# Altium schematic sheet DC_DC_5V_2.SchDoc
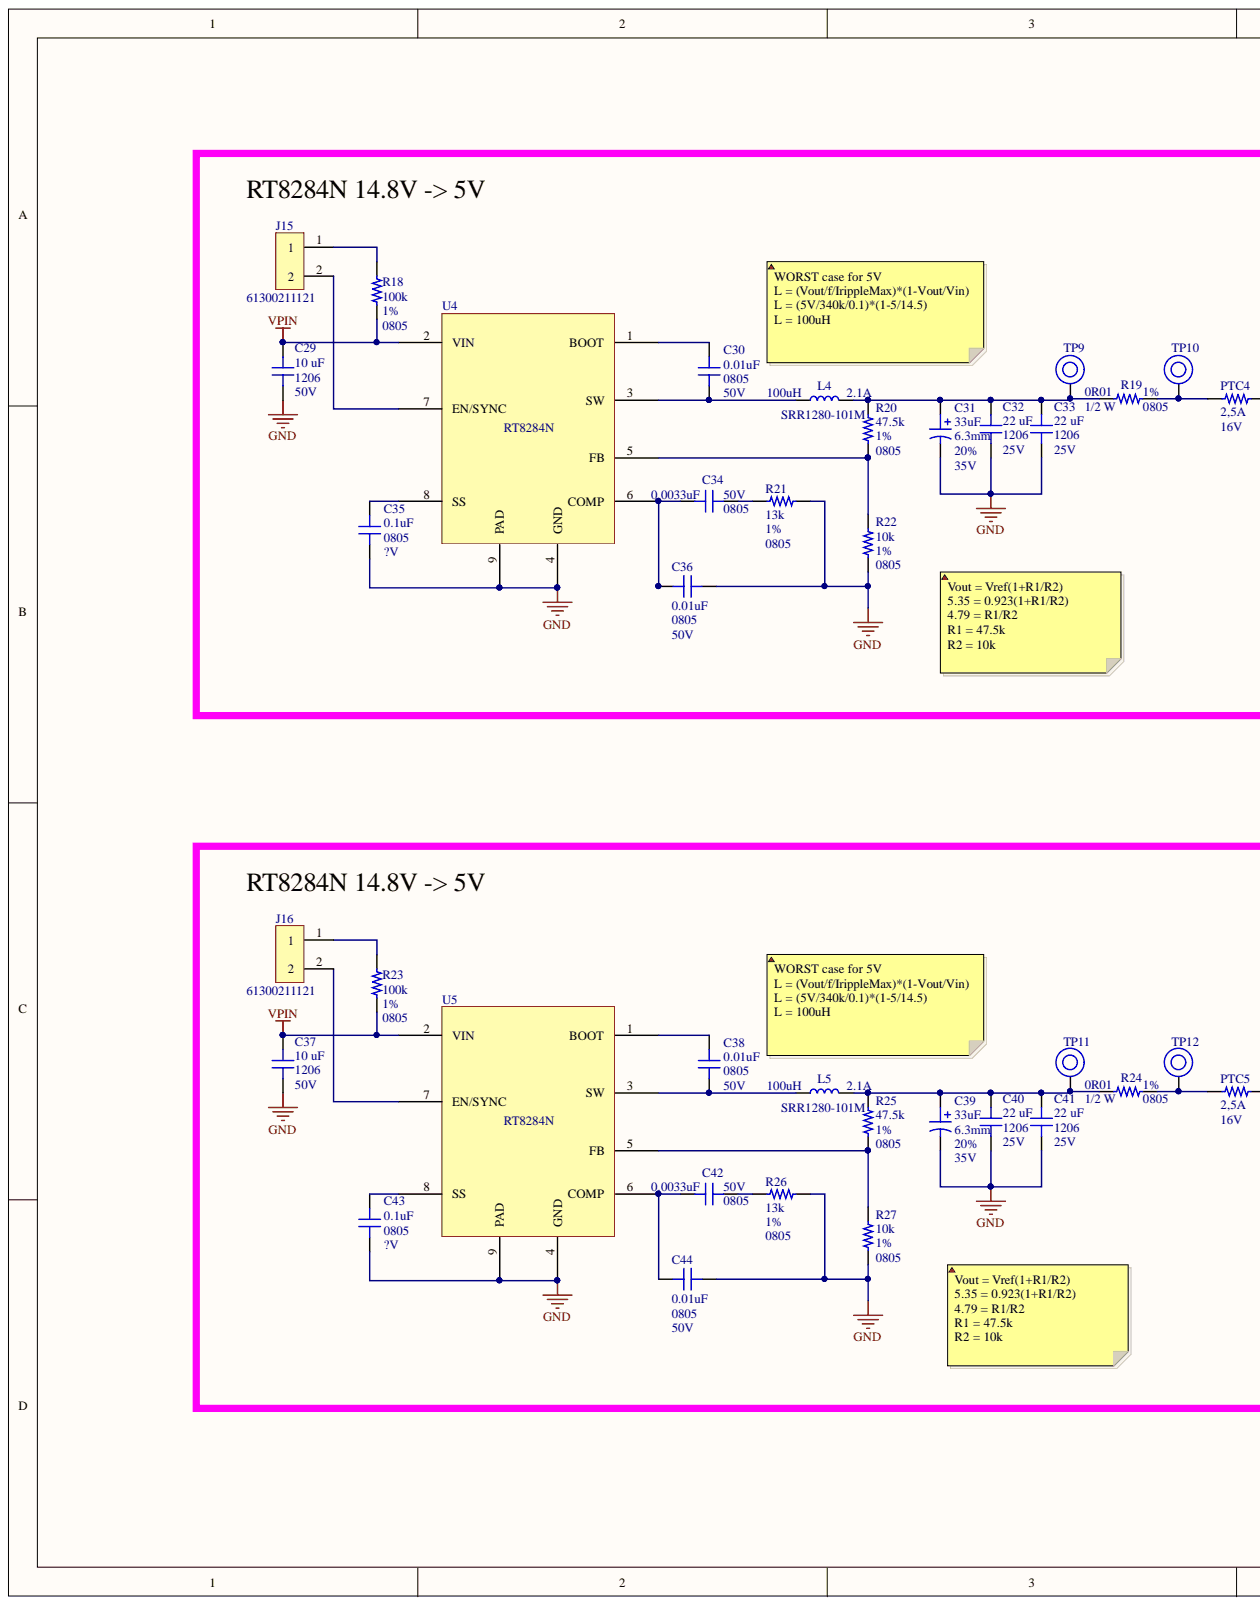
[diagram p0: DC_DC_5V_2.SchDoc, left]
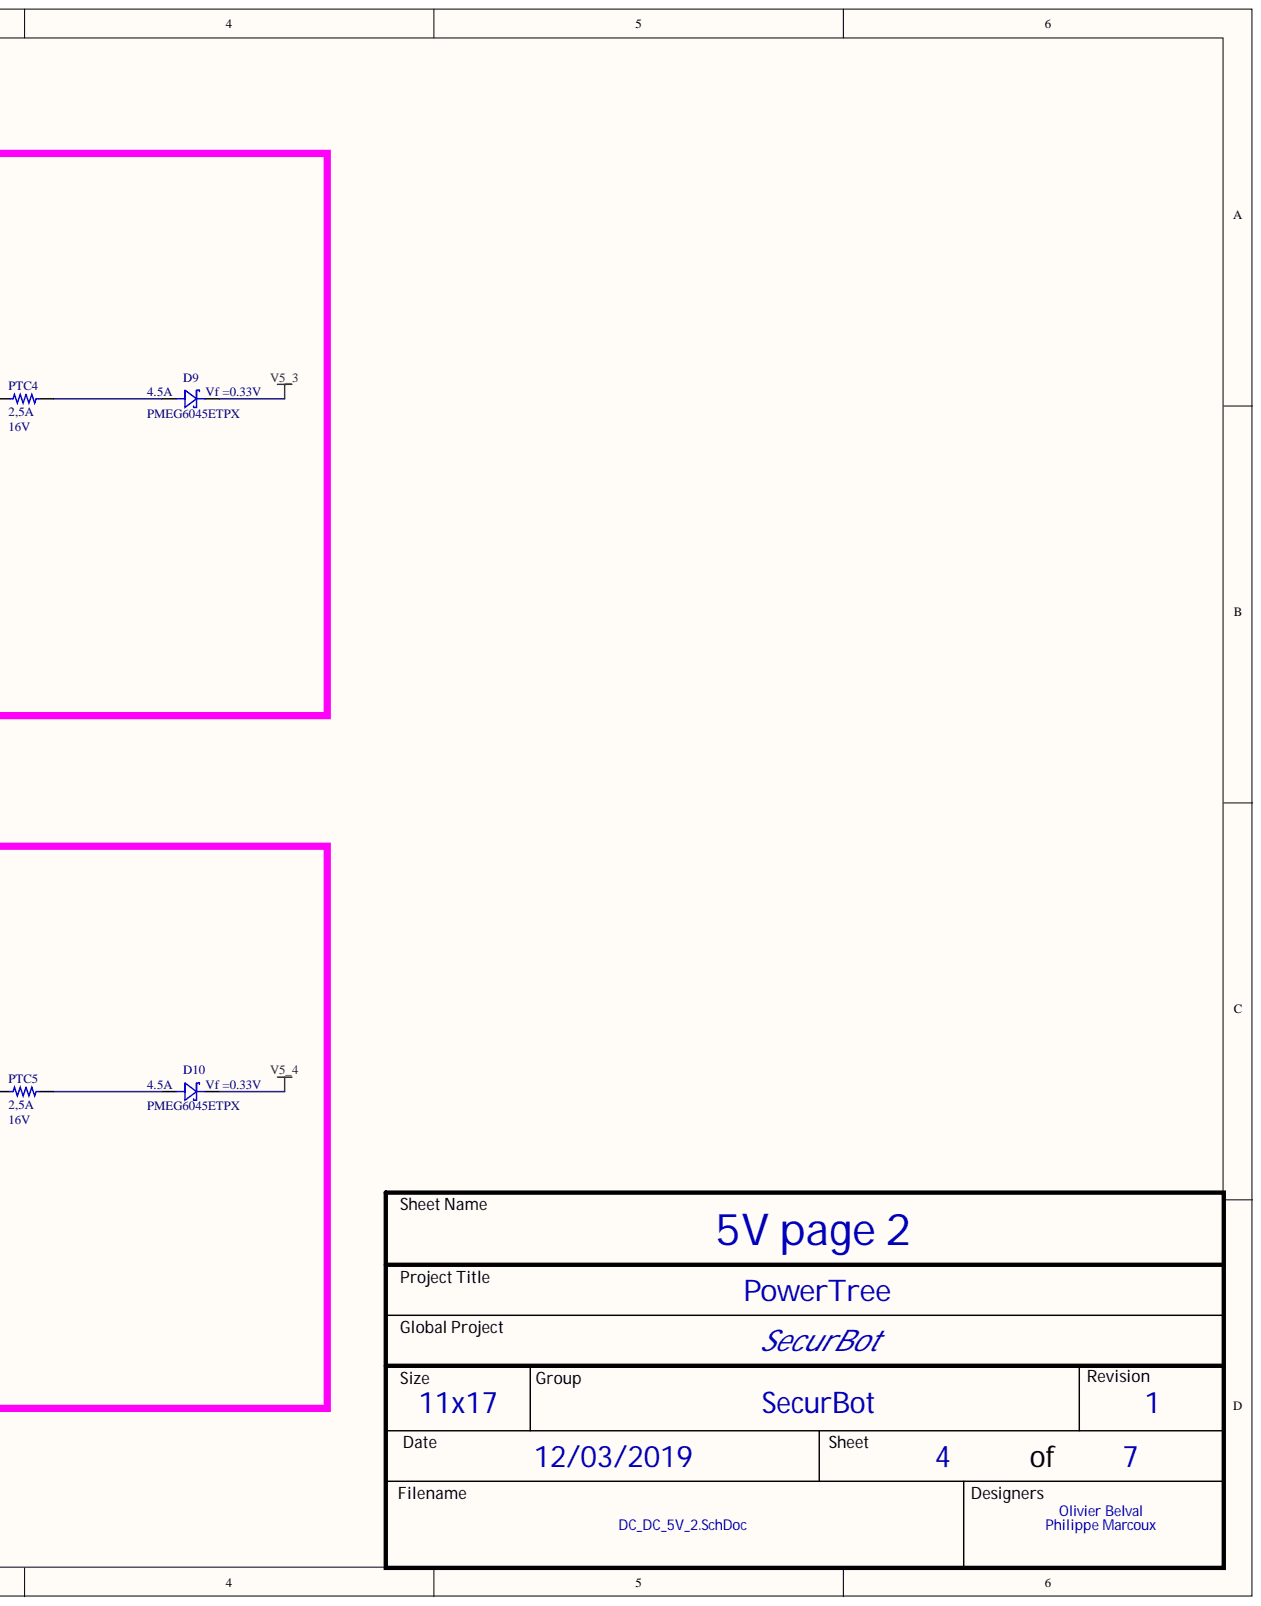
[diagram p1: DC_DC_5V_2.SchDoc, right]

## Parts
C29  value 10 uF  Package: 1206  Voltage: 50V  [CAP_1206]  (hidden comment: CAP - 1206)
C30  value 0.01uF  Package: 0805  Voltage: 50V  [CAP_0805]  (hidden comment: CAP - 0805)
C31  value 33uF  Package: 6.3mm  Tolerance: 20%  Voltage: 35V  [CAP ALU - 6.3mm DIA]  (hidden comment: CAP ALU - 6.3mm)
C32  value 22 uF  Package: 1206  Voltage: 25V  [CAP_1206]  (hidden comment: CAP - 1206)
C33  value 22 uF  Package: 1206  Voltage: 25V  [CAP_1206]  (hidden comment: CAP - 1206)
C34  value 0.0033uF  Package: 0805  Voltage: 50V  [CAP_0805]  (hidden comment: CAP - 0805)
C35  value 0.1uF  Package: 0805  Voltage: ?V  [CAP_0805]  (hidden comment: CAP - 0805)
C36  value 0.01uF  Package: 0805  Voltage: 50V  [CAP_0805]  (hidden comment: CAP - 0805)
C37  value 10 uF  Package: 1206  Voltage: 50V  [CAP_1206]  (hidden comment: CAP - 1206)
C38  value 0.01uF  Package: 0805  Voltage: 50V  [CAP_0805]  (hidden comment: CAP - 0805)
C39  value 33uF  Package: 6.3mm  Tolerance: 20%  Voltage: 35V  [CAP ALU - 6.3mm DIA]  (hidden comment: CAP ALU - 6.3mm)
C40  value 22 uF  Package: 1206  Voltage: 25V  [CAP_1206]  (hidden comment: CAP - 1206)
C41  value 22 uF  Package: 1206  Voltage: 25V  [CAP_1206]  (hidden comment: CAP - 1206)
C42  value 0.0033uF  Package: 0805  Voltage: 50V  [CAP_0805]  (hidden comment: CAP - 0805)
C43  value 0.1uF  Package: 0805  Voltage: ?V  [CAP_0805]  (hidden comment: CAP - 0805)
C44  value 0.01uF  Package: 0805  Voltage: 50V  [CAP_0805]  (hidden comment: CAP - 0805)
D9  Current: 4.5A  Part number: PMEG6045ETPX  Vf: Vf =0.33V  [DO-214AC_SOD128]  (hidden comment: SCHOTTKEY)
D10  Current: 4.5A  Part number: PMEG6045ETPX  Vf: Vf =0.33V  [DO-214AC_SOD128]  (hidden comment: SCHOTTKEY)
J15  Part number: 61300211121  [HDR1X2]  (hidden comment: Header 2 pin SM)
J16  Part number: 61300211121  [HDR1X2]  (hidden comment: Header 2 pin SM)
L4  value 100uH  Current: 2.1A  Part number: SRR1280-101M  [BOURNS 12.5x12.5mm]  (hidden comment: IND)
L5  value 100uH  Current: 2.1A  Part number: SRR1280-101M  [BOURNS 12.5x12.5mm]  (hidden comment: IND)
PTC4  Trip value: 2,5A  Voltage: 16V  [PTC - 1812]  (hidden comment: PTC - 1812)
PTC5  Trip value: 2,5A  Voltage: 16V  [PTC - 1812]  (hidden comment: PTC - 1812)
R18  value 100k  Package: 0805  Tolerance: 1%  [FER_0805]  (hidden comment: RES - 0805)
R19  value 0R01  Package: 0805  Tolerance: 1%  Watt: 1/2 W  [FER_0805]  (hidden comment: RES - 0805)
R20  value 47.5k  Package: 0805  Tolerance: 1%  [FER_0805]  (hidden comment: RES - 0805)
R21  value 13k  Package: 0805  Tolerance: 1%  [FER_0805]  (hidden comment: RES - 0805)
R22  value 10k  Package: 0805  Tolerance: 1%  [FER_0805]  (hidden comment: RES - 0805)
R23  value 100k  Package: 0805  Tolerance: 1%  [FER_0805]  (hidden comment: RES - 0805)
R24  value 0R01  Package: 0805  Tolerance: 1%  Watt: 1/2 W  [FER_0805]  (hidden comment: RES - 0805)
R25  value 47.5k  Package: 0805  Tolerance: 1%  [FER_0805]  (hidden comment: RES - 0805)
R26  value 13k  Package: 0805  Tolerance: 1%  [FER_0805]  (hidden comment: RES - 0805)
R27  value 10k  Package: 0805  Tolerance: 1%  [FER_0805]  (hidden comment: RES - 0805)
TP9  TestPoint 3x3mm  [TestPoint 3X3mm]  (value not drawn)
TP10  TestPoint 3x3mm  [TestPoint 3X3mm]  (value not drawn)
TP11  TestPoint 3x3mm  [TestPoint 3X3mm]  (value not drawn)
TP12  TestPoint 3x3mm  [TestPoint 3X3mm]  (value not drawn)
U4  RT8284N  [SOP8]
U5  RT8284N  [SOP8]

## Nets
GND: C29.2 C31.2 C32.2 C33.2 C35.2 C36.2 C37.2 C39.2 C40.2 C41.2 C43.2 C44.2 R21.2 R22.2 R26.2 R27.2 U4.4(GND) U4.9(PAD) U5.4(GND) U5.9(PAD)
V5_3: D9.2
V5_4: D10.2
VPIN: C29.1 C37.1 R18.1 R23.1 U4.2(VIN) U5.2(VIN)
NetC30_1: C30.1 U4.1(BOOT)
NetC30_2: C30.2 L4.1 U4.3(SW)
NetC31_1: C31.1 C32.1 C33.1 L4.2 R19.1 R20.1 TP9.1
NetC34_1: C34.1 C36.1 U4.6(COMP)
NetC34_2: C34.2 R21.1
NetC35_1: C35.1 U4.8(SS)
NetC38_1: C38.1 U5.1(BOOT)
NetC38_2: C38.2 L5.1 U5.3(SW)
NetC39_1: C39.1 C40.1 C41.1 L5.2 R24.1 R25.1 TP11.1
NetC42_1: C42.1 C44.1 U5.6(COMP)
NetC42_2: C42.2 R26.1
NetC43_1: C43.1 U5.8(SS)
NetD9_1: D9.1 PTC4.2
NetD10_1: D10.1 PTC5.2
NetJ15_1: J15.1 R18.2
NetJ15_2: J15.2 U4.7(EN/SYNC)
NetJ16_1: J16.1 R23.2
NetJ16_2: J16.2 U5.7(EN/SYNC)
NetPTC4_1: PTC4.1 R19.2 TP10.1
NetPTC5_1: PTC5.1 R24.2 TP12.1
NetR20_2: R20.2 R22.1 U4.5(FB)
NetR25_2: R25.2 R27.1 U5.5(FB)

## Library data of the parts
C29 C37 = CAP - 1206: Part number: GRT31CR61H106ME01L; Component Kind: Standard; Description: CAP - 1206; Library Name: SecureBot.SchLib
C30 C36 C38 C44 = CAP - 0805: Part number: CL21B103KBANNNC; Component Kind: Standard; Description: CAP - 0805; Library Name: SecureBot.SchLib
C31 C39 = CAP ALU - 6_3mm: Part number: EEE-FK1V330P; Component Kind: Standard; Description: CAP ALU - 6.3mm; Library Name: SecureBot.SchLib
C32 C33 C40 C41 = CAP - 1206: Part number: CL31A226KAHNNNE; Component Kind: Standard; Description: CAP - 1206; Library Name: SecureBot.SchLib
C34 C42 = CAP - 0805: Part number: CL21B332KBANNNC; Component Kind: Standard; Description: CAP - 0805; Library Name: SecureBot.SchLib
C35 C43 = CAP - 0805: Part number: CC0805KRX7R9BB104; Component Kind: Standard; Description: CAP - 0805; Library Name: SecureBot.SchLib
PTC4 PTC5 = PTC - 1812: Part number: 0ZCG0160BF2C; Component Kind: Standard; Description: PTC - 1812; Package: 1812; Res: ?; Library Name: SecureBot.SchLib
R18 R23 = RES - 0805: Part number: RMCF0805FT100K; Watt: ? W; Component Kind: Standard; Description: RES - 0805; Library Name: SecureBot.SchLib
R19 R24 = RES - 0805: Part number: KRL1220E-M-R010-F-T5; Component Kind: Standard; Description: RES - 0805; Library Name: SecureBot.SchLib
R20 R25 = RES - 0805: Part number: RMCF0805FT47K5; Watt: ? W; Component Kind: Standard; Description: RES - 0805; Library Name: SecureBot.SchLib
R21 R26 = RES - 0805: Part number: RC0805FR-0713KL; Watt: ? W; Component Kind: Standard; Description: RES - 0805; Library Name: SecureBot.SchLib
R22 R27 = RES - 0805: Part number: RMCF0805FT10K0; Watt: ? W; Component Kind: Standard; Description: RES - 0805; Library Name: SecureBot.SchLib
D9 D10 = SCHOTTKEY: Component Kind: Standard; Library Name: SecureBot.SchLib
J15 J16 = Header 2 pin SM: Component Kind: Standard; Library Name: SecureBot.SchLib
L4 L5 = IND: Component Kind: Standard; Description: IND; Package: ?; Library Name: SecureBot.SchLib
TP9-TP12 = TestPoint 3x3mm: Component Kind: Standard; Library Name: SecureBot.SchLib
U4 U5 = RT8284N: Component Kind: Standard; Description: RT8284N; Part number: RT8284N; Library Name: SecureBot.SchLib
